# Altium schematic sheet External_Power_schematic.SchDoc
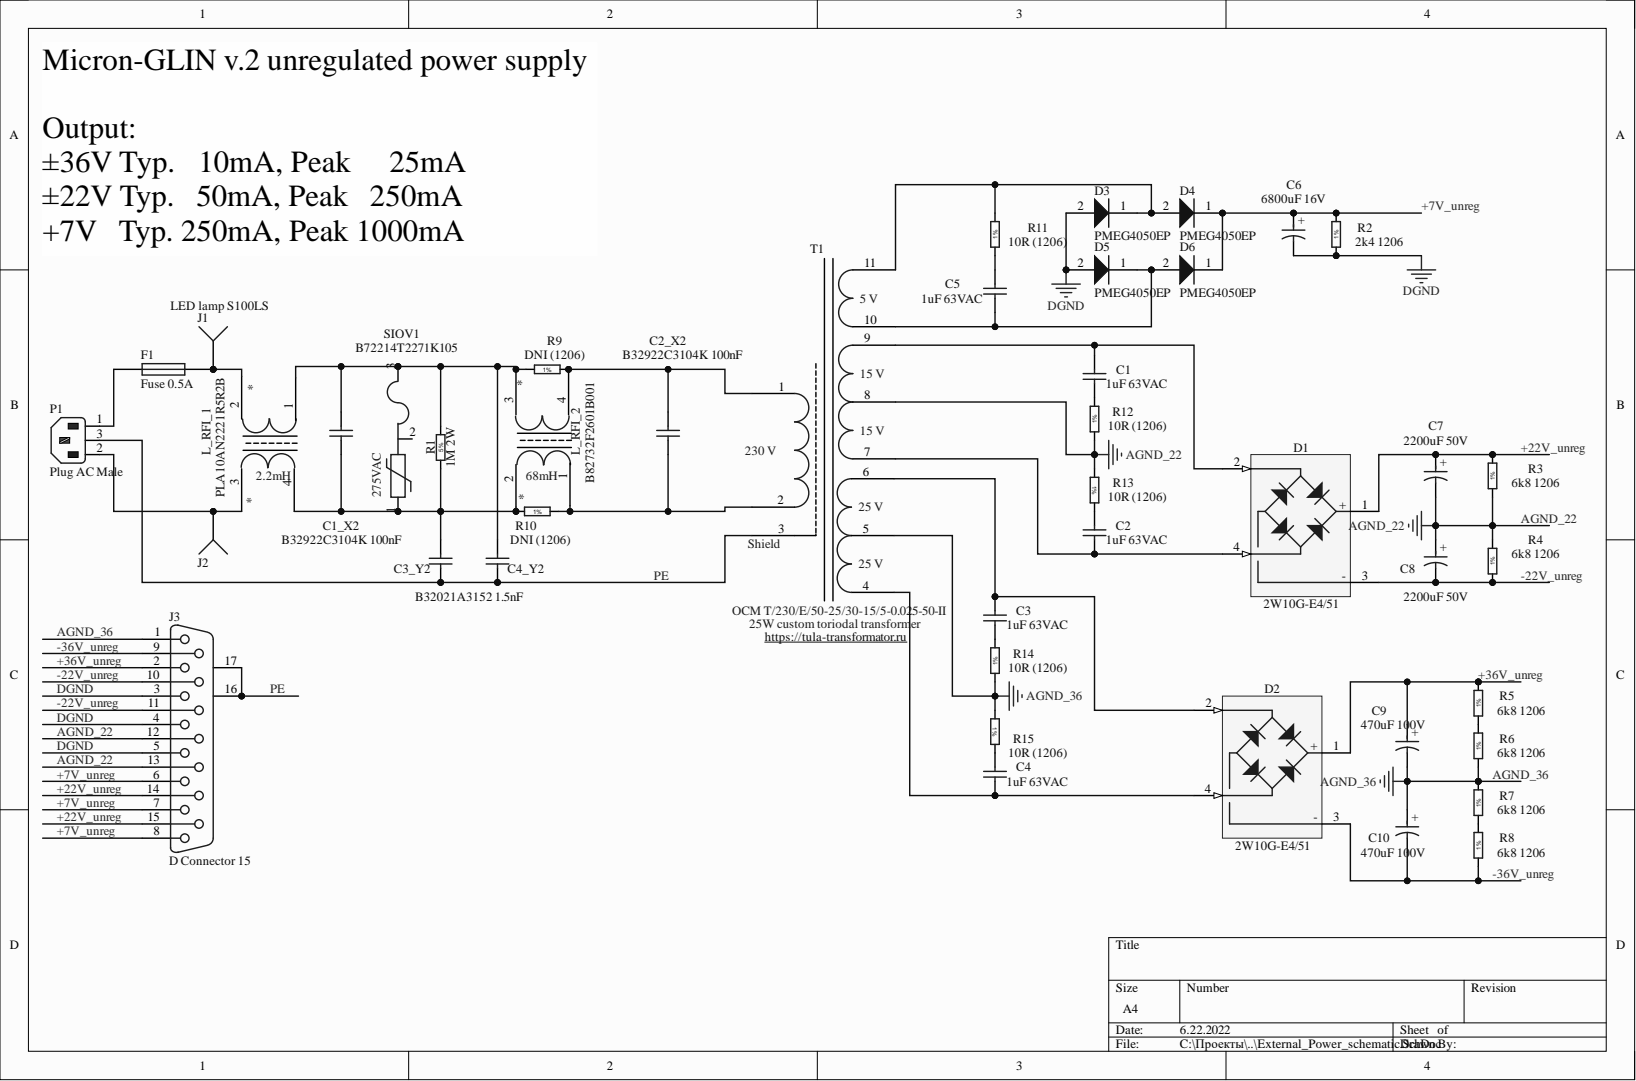
[diagram p0: External_Power_schematic.SchDoc]

## Parts
C1  1uF 63VAC  [CAPR2250-1050X2650X1650]
C1_X2  B32922C3104K 100nF  [CAPR2250-1050X2650X1650]
C2  1uF 63VAC  [CAPR2250-1050X2650X1650]
C2_X2  B32922C3104K 100nF  [CAPR2250-1050X2650X1650]
C3  1uF 63VAC  [CAPR2250-1050X2650X1650]
C3_Y2  B32021A3152 1.5nF  [CAPR2250-1050X2650X1650]
C4  1uF 63VAC  [CAPR2250-1050X2650X1650]
C4_Y2  B32021A3152 1.5nF  [CAPR2250-1050X2650X1650]
C5  1uF 63VAC  [CAPR2250-1050X2650X1650]
C6  6800uF 16V  Voltage: 16V  [CAPPR750-1850X3750]
C7  2200uF 50V  [CAPPR750-1850X3750]
C8  2200uF 50V  [CAPPR750-1850X3750]
C9  470uF 100V  [CAPPR750-1850X3750]
C10  470uF 100V  [CAPPR750-1850X3750]
D1  2W10G-E4/51  [VISHAY_WOG]
D2  2W10G-E4/51  [VISHAY_WOG]
D3  PMEG4050EP  [PMEG4050EP]
D4  PMEG4050EP  [PMEG4050EP]
D5  PMEG4050EP  [PMEG4050EP]
D6  PMEG4050EP  [PMEG4050EP]
F1  Fuse 0.5A  [FUSE]
J1  Socket  [PIN1]  (value not drawn)
J2  Socket  [PIN1]  (value not drawn)
J3  D Connector 15  System: Connector  [DSUB1.385-2H15]
L_RFI_1  PLA10AN2221R5R2B  [PLA10AN2221R5R2B]
L_RFI_2  B82732F2601B001  [B82732F]
P1  Plug AC Male  [AS-205]
R1  1M 2W  Power: 2W  [RES_TYCO_CFR200]
R2  2k4 1206  [RESC3216X65N]
R3  6k8 1206  [RESC3216X65N]
R4  6k8 1206  [RESC3216X65N]
R5  6k8 1206  [RESC3216X65N]
R6  6k8 1206  [RESC3216X65N]
R7  6k8 1206  [RESC3216X65N]
R8  6k8 1206  [RESC3216X65N]
R9  DNI (1206)  [RESC3216X65N]
R10  DNI (1206)  [RESC3216X65N]
R11  10R (1206)  [RESC3216X65N]
R12  10R (1206)  [RESC3216X65N]
R13  10R (1206)  [RESC3216X65N]
R14  10R (1206)  [RESC3216X65N]
R15  10R (1206)  [RESC3216X65N]
SIOV1  B72214T2271K105  [B72214T2271K105]
T1  OCM T/230/E/50-25/30-15/5-0.025-50-II  [OCM T/230/E/50-25/30-15/5-0.025-50-II]

## Nets
+7V_unreg: C6.1 D4.1 D6.1 J3.6 J3.7 J3.8 R2.2
+22V_unreg: C7.1 D1.1 J3.14 J3.15 R3.2
+36V_unreg: C9.1 D2.1 J3.2 R5.2
-22V_unreg: C8.2 D1.3 J3.10 J3.11 R4.1
-36V_unreg: C10.2 D2.3 J3.9 R8.1
AGND_22: C7.2 C8.1 J3.12 J3.13 R3.1 R4.2 R12.1 R13.1 T1.8
AGND_36: C9.2 C10.1 J3.1 R6.1 R7.2 R14.1 R15.1 T1.5
DGND: C6.2 D3.2 D5.2 J3.3 J3.4 J3.5 R2.1
PE: C3_Y2.1 C4_Y2.1 J3.16 J3.17 P1.3 T1.3
NetC1_1: C1.1 R12.2
NetC1_2: C1.2 D1.2 T1.9
NetC1_X2_1: C1_X2.1 C3_Y2.2 L_RFI_1.4 L_RFI_2.2 R1.1 R10.1 SIOV1.1
NetC1_X2_2: C1_X2.2 C4_Y2.2 L_RFI_1.1 L_RFI_2.3 R1.2 R9.1 SIOV1.3
NetC2_1: C2.1 D1.4 T1.7
NetC2_2: C2.2 R13.2
NetC2_X2_1: C2_X2.1 L_RFI_2.1 R10.2 T1.2
NetC2_X2_2: C2_X2.2 L_RFI_2.4 R9.2 T1.1
NetC3_1: C3.1 R14.2
NetC3_2: C3.2 D2.2 T1.6
NetC4_1: C4.1 D2.4 T1.4
NetC4_2: C4.2 R15.2
NetC5_1: C5.1 D5.1 D6.2 T1.10
NetC5_2: C5.2 R11.1
NetD3_1: D3.1 D4.2 R11.2 T1.11
NetF1_1: F1.1 P1.1
NetF1_2: F1.2 J1.1 L_RFI_1.2
NetJ2_1: J2.1 L_RFI_1.3 P1.2
NetR5_1: R5.1 R6.2
NetR7_1: R7.1 R8.2

Not connected: SIOV1 2

## Library data of the parts
C1-C5 = CPPR_330NF_630V_5%_2250-1050X2650X1650: Non-polarised capacitor; Manufacturer: TDK; Manufacturer Part Number: B32653A6334J000; Part Description: Metallized Polypropylene Film Capacitor (MKP); Database Table Name: Capacitors THD; Tolerance: ±5%; Voltage: 630VDC/250VAC; Case: LS22.5 W10.5 x L26.5 x H16.5; Component Kind: Standard; Component Type: Standard; ComponentHeight: 16.5mm; CreateDate: 20.12.2007; HelpURL: \\cern.ch\dfs\Applications\Altium\Datasheets\CPPR_TDK_B32653.pdf; LatestRevisionDate: 22.04.2015; Library Name: Capacitors.DbLib; Mounted: Yes; Part Number: CPPR_330NF_630V_5%_2250-1050X2650X1650; Pin Count: 2; Sense: No; SMD: No; Socket: No; Status: None; Value: 1uF 63VAC; Author: CERN DEM JLC
C1_X2 C2_X2 C3_Y2 C4_Y2 = CPPR_330NF_630V_5%_2250-1050X2650X1650: Non-polarised capacitor; Manufacturer: TDK; Manufacturer Part Number: B32653A6334J000; Part Description: Metallized Polypropylene Film Capacitor (MKP); Database Table Name: Capacitors THD; Tolerance: ±5%; Value: 330nF; Voltage: 630VDC/250VAC; Case: LS22.5 W10.5 x L26.5 x H16.5; Component Kind: Standard; Component Type: Standard; ComponentHeight: 16.5mm; CreateDate: 20.12.2007; HelpURL: \\cern.ch\dfs\Applications\Altium\Datasheets\CPPR_TDK_B32653.pdf; LatestRevisionDate: 22.04.2015; Library Name: Capacitors.DbLib; Mounted: Yes; Part Number: CPPR_330NF_630V_5%_2250-1050X2650X1650; Pin Count: 2; Sense: No; SMD: No; Socket: No; Status: None; Author: CERN DEM JLC
C6 = CAER_10000UF_16V_20%_CHEMI-CON_EKYB160ELL103MMP1S: Polarised capacitor; Manufacturer: UNITED CHEMI-CON; Part Description: Z=0R012 Miniature Aluminium Electrolytic Capacitor; Database Table Name: Capacitors THD; Tolerance: ±20%; Case: LS7.5 D18 x H35.5; Component Kind: Standard; Component Type: Standard; ComponentHeight: 37mm; CreateDate: 02.06.2015; HelpURL: \\cern.ch\dfs\Applications\Altium\Datasheets\CAER_CHEMI-CON_KYB.pdf; LatestRevisionDate: 02.06.2015; Library Name: Capacitors.DbLib; Manufacturer Part Number: EKYB160ELL103MMP1S; Mounted: Yes; Part Number: CAER_10000UF_16V_20%_CHEMI-CON_EKYB160ELL103MMP1S; Pin Count: 2; Sense: Yes; SMD: No; Socket: No; Status: None; Value: 6800uF 16V; Author: CERN DEM JLC
C7-C10 = CAER_10000UF_16V_20%_CHEMI-CON_EKYB160ELL103MMP1S: Polarised capacitor; Manufacturer: UNITED CHEMI-CON; Part Description: Z=0R012 Miniature Aluminium Electrolytic Capacitor; Database Table Name: Capacitors THD; Tolerance: ±20%; Voltage: 16V; Case: LS7.5 D18 x H35.5; Component Kind: Standard; Component Type: Standard; ComponentHeight: 37mm; CreateDate: 02.06.2015; HelpURL: \\cern.ch\dfs\Applications\Altium\Datasheets\CAER_CHEMI-CON_KYB.pdf; LatestRevisionDate: 02.06.2015; Library Name: Capacitors.DbLib; Manufacturer Part Number: EKYB160ELL103MMP1S; Mounted: Yes; Part Number: CAER_10000UF_16V_20%_CHEMI-CON_EKYB160ELL103MMP1S; Pin Count: 2; Sense: Yes; SMD: No; Socket: No; Status: None; Author: CERN DEM JLC
  C7 C8: Value: 2200uF 50V
  C9 C10: Value: 470uF 100V
D1 D2 = W005G-E4/51: Bridge Rectifier 1+ 2AC 3- 4AC; Manufacturer: VISHAY GENERAL SEMICONDUCTOR; Part Description: Glass Passivated Single-Phase Bridge Rectifier; Bonding: No; Database Table Name: Diodes; Power: 1.5A; PressFit: No; Voltage: 50V; Component Kind: Standard; Component Type: Standard; ComponentHeight: 13.5mm; CreateDate: 20.09.2011; Device: 2W10G-E4/51; HelpURL: \\cern.ch\dfs\Applications\Altium\Datasheets\W005G-E4-51.pdf; LatestRevisionDate: 08.04.2016; Library Name: ICs And Semiconductors.DbLib; Manufacturer Part Number: W005G-E4/51; Mounted: Yes; PackageDescription: Bridge Rectifier, Body 9.35m x 8.33mm, VISHAY Case Type WOG; Part Number: W005G-E4/51; Pin Count: 4; Sense: No; SMD: No; Socket: No; Author: CERN DEM JLC
F1 = Fuse 1: Fuse; Component Kind: Standard; LatestRevisionDate: 17-Jul-2002; LatestRevisionNote: Re-released for DXP Platform.; Library Name: Miscellaneous Devices.IntLib; PackageDescription: Thru-Hole; 2 Leads; PackageReference: PIN-W2/E2.8; Published: 8-Jun-2000; Simulation: FUSE; Publisher: Altium Limited
J3 = D Connector 15: Receptacle Assembly, 15 Position, Right Angle; PackageDocument: O; Positions: 15; Component Kind: Standard; DatasheetDocument: 1-Sep-99; Gender: Receptacle; LatestRevisionDate: 17-Jul-2002; LatestRevisionNote: Re-released for DXP Platform.; Library Name: Miscellaneous Connectors.SchLib; Orientation: Right Angle; PackageReference: 788757; Published: 15-Jun-2001; Signal Integrity: Connector; Publisher: Altium Limited
L_RFI_2 = PLA10AN2221R5R2B: Component Kind: Standard; Library Name: My SCH library.SchLib
P1 = Plug AC Male: IEC Mains Power Inlet, EN60 320-1 C14 Class I Cold Condition, PC Flange Rear Mount, Chassis Plug; DrillSize: Corresponds to nominal value.; MaximumRating: 10A, 250V a.c. (UL & CSA 15A, 250V a.c.); MountingFixtures: Not drawn on schematic symbol.; Note: IEC Color Scheme employed for L, N and E.; PackageDocument: ver.80, 15-Sep-2003; PCB_Layout: Complies with manufacturer's recommendation.; Component Kind: Standard; ComponentLink1Description: Manufacturer Link; ComponentLink1URL: http://www.bulgin.co.uk/; DatasheetDocument: ver.80, 15-Sep-2003; LatestRevisionDate: 15-Jul-2004; LatestRevisionNote: Component Replaced.; Library Name: Miscellaneous Connectors.SchLib; PackageReference: PX0580/PC; Published: 15-Jul-2004; Terminations: P.C. Spills; Publisher: Altium Limited
R1 = RCF_NO-VALUE_5%_2W_0-450PPM_TYCO_CFR200: Resistor - 5%; Manufacturer: TYCO ELECTRONICS; Manufacturer Part Number: CFR200J; Part Description: Carbon Film Fixed Resistor - CFR  Series; Database Table Name: Resistors THD; Mounted: No; PressFit: No; TC: 0 -450ppm/°C; Case: LS20.32 L16 x D5.5; Component Kind: Standard; Component Type: Standard; ComponentHeight: 5.5mm; CreateDate: 10.03.2014; HelpURL: \\cern.ch\dfs\Applications\Altium\Datasheets\RCF_TYCO_CFR200.pdf; LatestRevisionDate: 03.06.2014; Library Name: Resistors.DbLib; Part Number: RCF_NO-VALUE_5%_2W_0-450PPM_TYCO_CFR200; Pin Count: 2; Sense: No; SMD: No; Socket: No; Status: None; Tolerance: ±5%; Value: 1M 2W; Author: CERN DEM JLC
R2-R15 = R1206_2K4_1%_0.25W_100PPM: Resistor - 1%; Manufacturer: GENERIC; Manufacturer1 Part Number: NRC12F2401TRF; Part Description: General Purpose Thick Film Chip Resistor; Database Table Name: Resistors SMD; Power: 0.25W; PressFit: No; TC: ±100ppm/°C; Case: 1206; Component Kind: Standard; Component Type: Standard; CreateDate: 03.12.2007; HelpURL: \\cern.ch\dfs\Applications\Altium\Datasheets\R1206_NIC_NRC.pdf; LatestRevisionDate: 13.03.2008; Library Name: Resistors.DbLib; Manufacturer1 ComponentHeight: 0.65mm; Manufacturer1 Example: NIC COMPONENT; Manufacturer Part Number: R1206_2K4_1%_0.25W_100PPM; Mounted: Yes; Part Number: R1206_2K4_1%_0.25W_100PPM; Pin Count: 2; Sense: No; SMD: Yes; Socket: No; Status: Preferred; Tolerance: ±1%; Author: CERN DEM JLC
  R2: Value: 2k4 1206
  R3-R8: Value: 6k8 1206
  R9 R10: Value: DNI (1206)
  R11-R15: Value: 10R (1206)
D3-D6 = PMEG4050EP: Component Kind: Standard; Library Name: My SCH library.SchLib
J1 J2 = Socket: Component Kind: Standard; Description: Socket; LatestRevisionDate: 17-Jul-2002; LatestRevisionNote: Re-released for DXP Platform.; Library Name: Miscellaneous Connectors.SchLib; Signal Integrity: Connector; Publisher: Altium Limited
L_RFI_1 = PLA10AN2221R5R2B: Component Kind: Standard; Library Name: My SCH library.SchLib
SIOV1 = B72214T2271K105: Component Kind: Standard; LatestRevisionDate: 29-May-2009; LatestRevisionNote: IPC-7351 Footprint Added.; Library Name: My SCH library.SchLib; Order: B72214T2271K105; PackageDescription: Chip Resistor; PackageReference: J1-0603; Published: 8-Jun-2000; Publisher: Altium Limited
T1 = OCM T/230/E/50-25/30-15/5-0.025-50-II: Component Kind: Standard; Description: OCM T/230/E/50-25/30-15/5-0.025-50-II; Library Name: My SCH library.SchLib
